# Altium schematic sheet NORFLASH.SchDoc
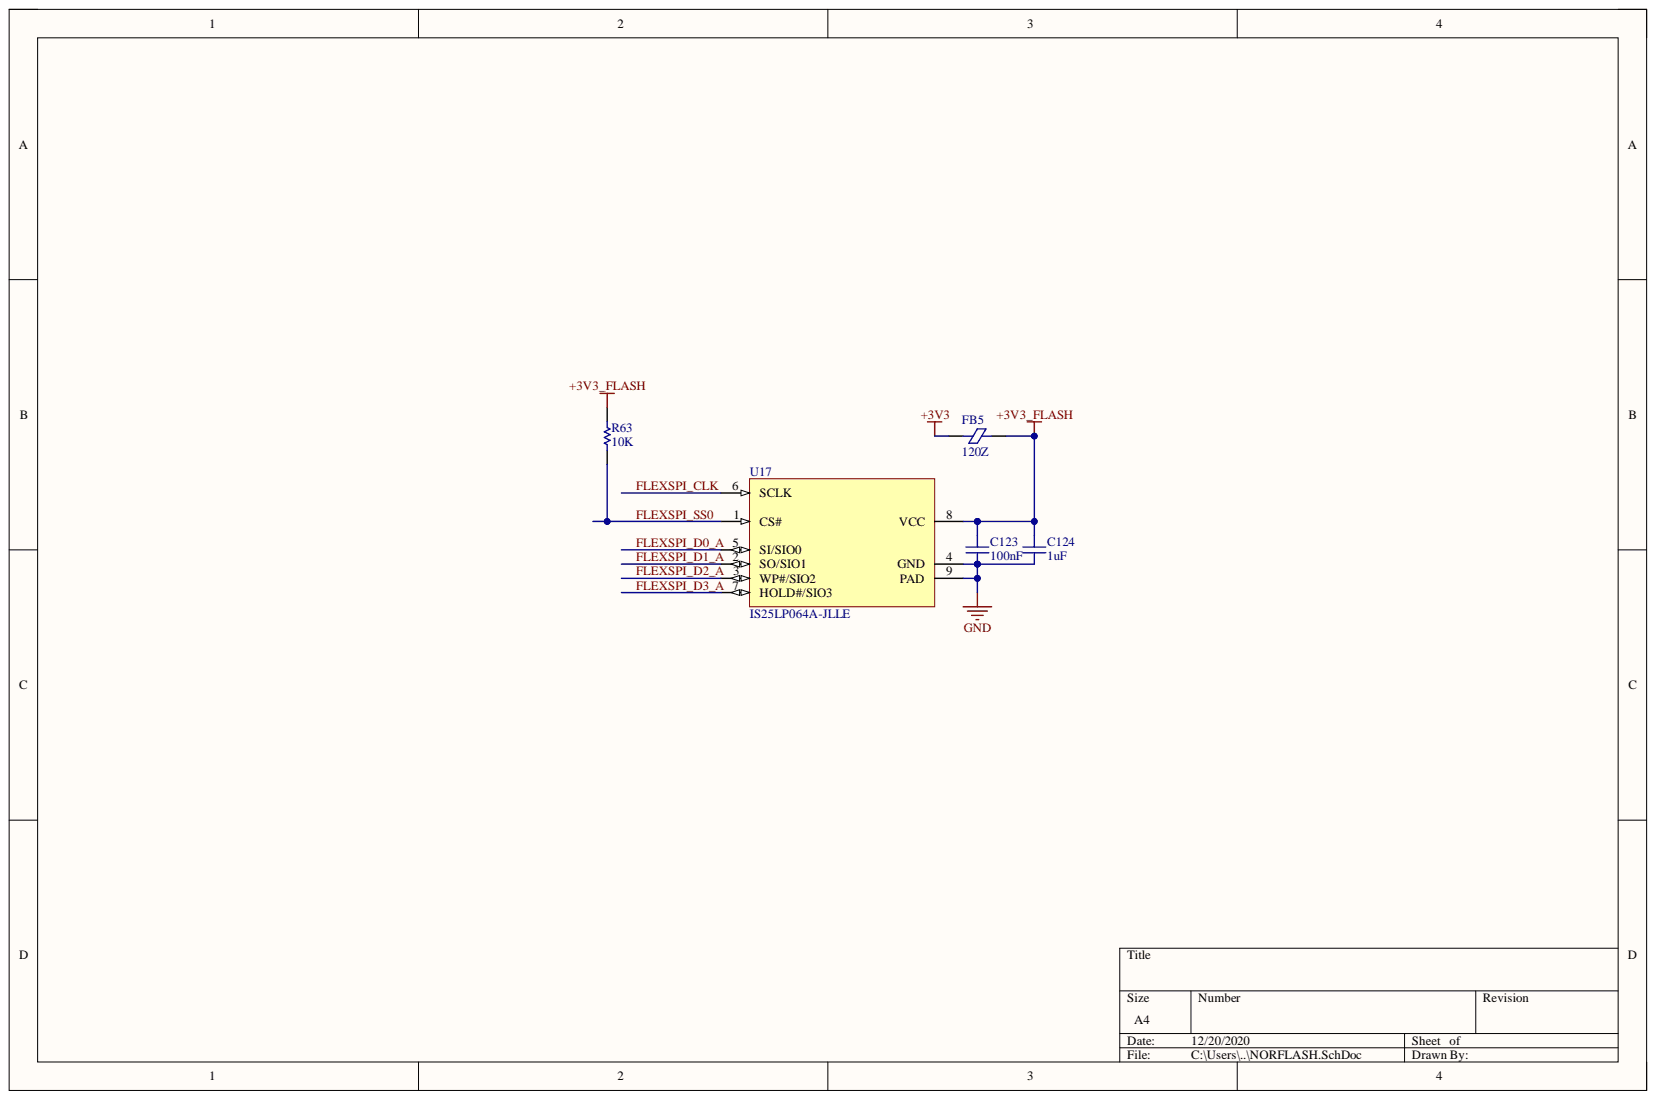
[diagram p0: NORFLASH.SchDoc]

## Parts
C123  100nF  [AA-0402]
C124  1uF  [AA-0402]
FB5  120Z  [AA-0402]
R63  10K  [AA-0402]
U17  IS25LP064A-JLLE  [WSON-8L]

## Nets
+3V3: FB5.1
+3V3_FLASH: C123.2 C124.2 FB5.2 R63.2 U17.8(VCC)
GND: C123.1 C124.1 U17.4(GND) U17.9(PAD)
FLEXSPI_CLK: U17.6(SCLK)
FLEXSPI_D0_A: U17.5(SI/SIO0)
FLEXSPI_D1_A: U17.2(SO/SIO1)
FLEXSPI_D2_A: U17.3(WP#/SIO2)
FLEXSPI_D3_A: U17.7(HOLD#/SIO3)
FLEXSPI_SS0: R63.1 U17.1(CS#)

## Library data of the parts
C123 C124 = 00005453: Database Table Name: Capacitor; Component Kind: Standard; Library Name: ALT_DB.DBLIB
FB5 = F_BEAD: PSpiceTemplate: L^@REFDES %1 %2 ?TOLERANCE|L^@REFDES| @VALUE ?IC/IC=@IC/ ?TOLERANCE|\n.model l^@REFDES IND L=1 DEV=@TOLERANCE%|; Component Kind: Standard; Simulation: L_0402; Library Name: ULTIMA_LIB.SCHLIB
R63 = 10012076Wa: Database Table Name: Resistor; Component Kind: Standard; Library Name: ALT_DB.DBLIB; Signal Integrity: Res; Simulation: RESISTOR; Value: 10K
U17 = (no symbol name): Component Kind: Standard; Library Name: ULTIMA_LIB.SCHLIB
